# Altium schematic sheet [1]MCU.SchDoc([1]MCU)
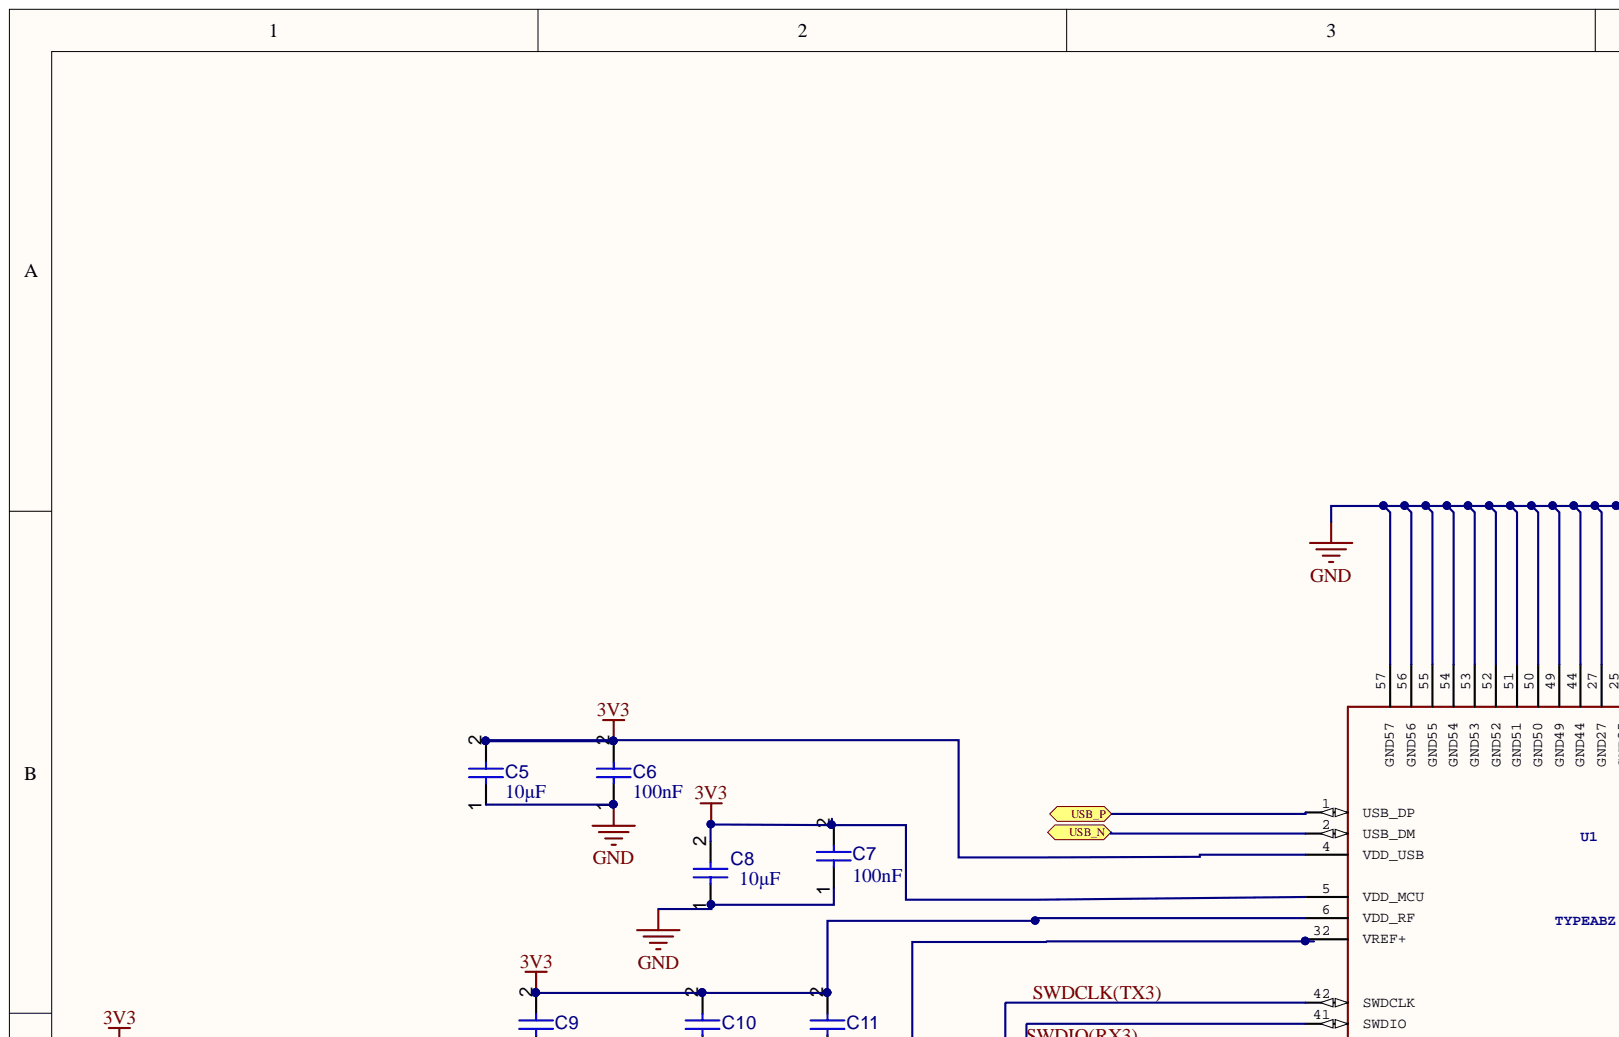
[diagram p0: [1]MCU.SchDoc, top left]
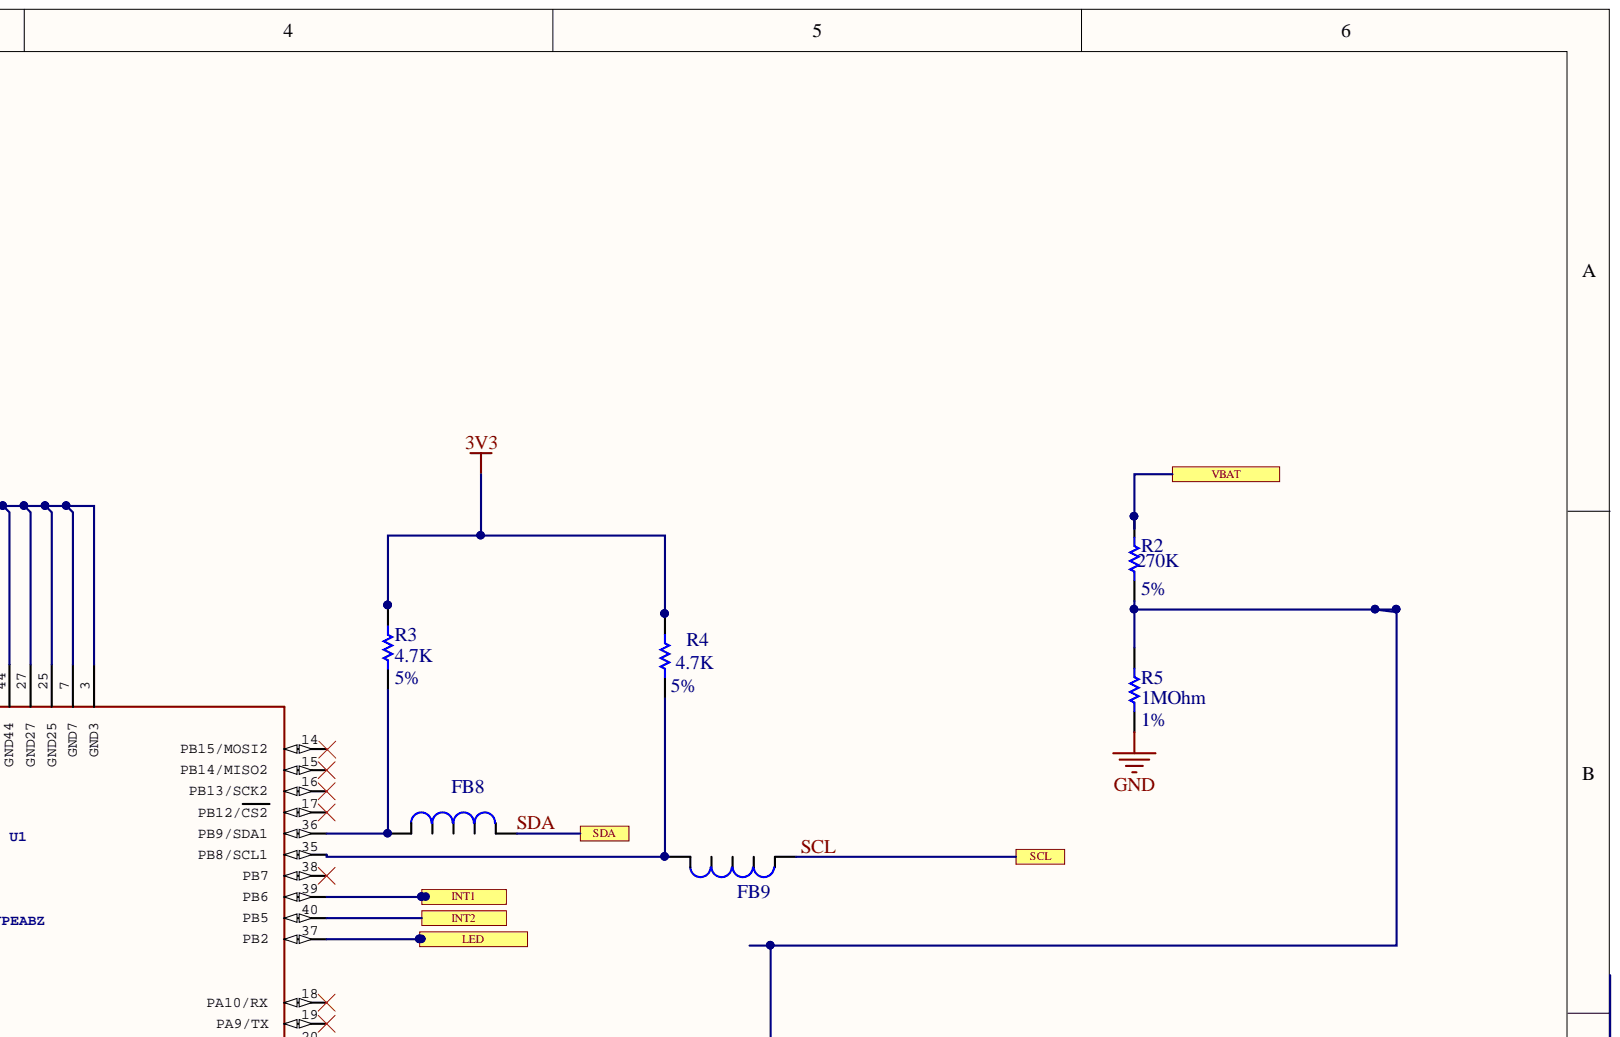
[diagram p1: [1]MCU.SchDoc, top right]
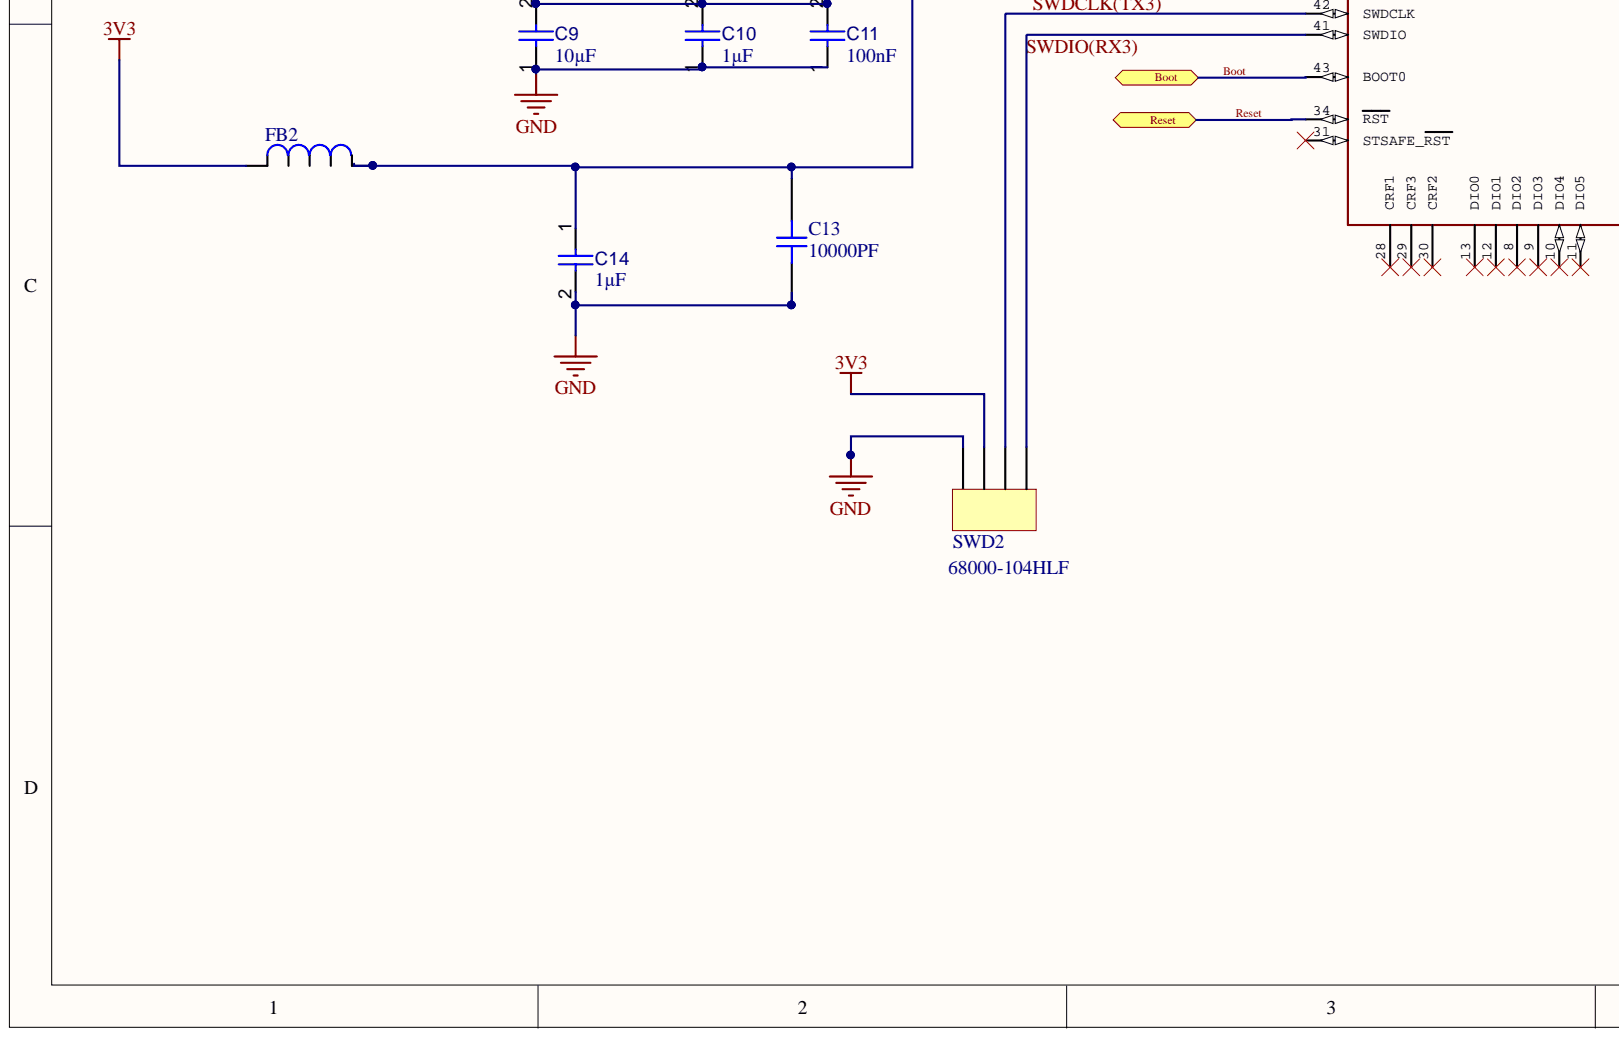
[diagram p2: [1]MCU.SchDoc, bottom left]
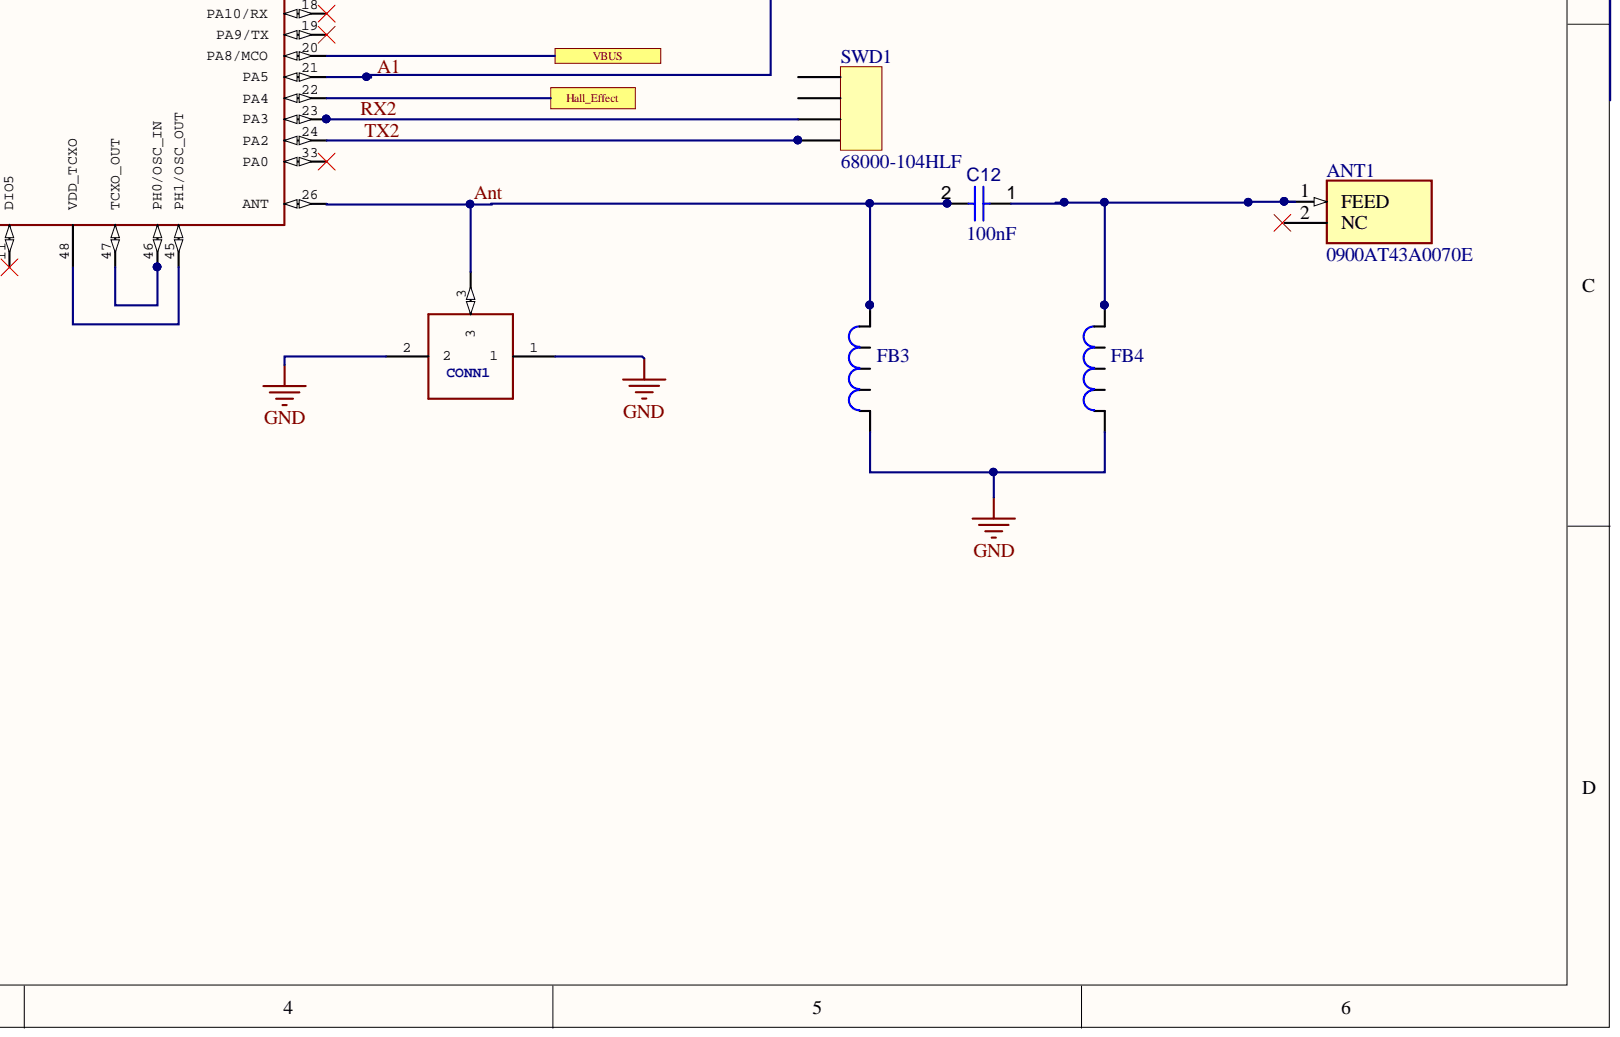
[diagram p3: [1]MCU.SchDoc, bottom right]

## Parts
ANT1  0900AT43A0070E  [ANTC7020X90N]
C5  value 10µF  [CAPC0603(1608)100_L]  (hidden comment: Capacitor 10µF +/-20% 10V 0603)
C6  value 100nF  [CAPC1608X90X40ML10T20]  (hidden comment: Capacitor 100 nF +/-10% 50 V 0603)
C7  value 100nF  [CAPC1608X90X40ML10T20]  (hidden comment: Capacitor 100 nF +/-10% 50 V 0603)
C8  value 10µF  [CAPC0603(1608)100_L]  (hidden comment: Capacitor 10µF +/-20% 10V 0603)
C9  value 10µF  [CAPC0603(1608)100_L]  (hidden comment: Capacitor 10µF +/-20% 10V 0603)
C10  value 1µF  [CAPC1608X90X40ML10T20]  (hidden comment: Capacitor 1 uF +/- 10% 25 V 0603)
C11  value 100nF  [CAPC1608X90X40ML10T20]  (hidden comment: Capacitor 100 nF +/-10% 50 V 0603)
C12  value 100nF  [CAPC1608X90X40ML10T20]  (hidden comment: Capacitor 100 nF +/-10% 50 V 0603)
C13  10000PF  [CAPC0603]
C14  value 1µF  [CAPC1608X90X40ML10T20]  (hidden comment: Capacitor 1 uF +/- 10% 25 V 0603)
CONN1  U.FL-R-SMT-1  [U.FL-R-SMT-1]  (value not drawn)
FB2  Ferrite Bead  [Ferrite Bead]  (value not drawn)
FB3  Ferrite Bead  [Ferrite Bead]  (value not drawn)
FB4  Ferrite Bead  [Ferrite Bead]  (value not drawn)
FB8  Ferrite Bead  [Ferrite Bead]  (value not drawn)
FB9  Ferrite Bead  [Ferrite Bead]  (value not drawn)
R2  value 270K  Tolerance: 5%  [RES 0603]  (hidden comment: RES 270K 5% 0.1W [0603])
R3  value 4.7K  Tolerance: 5%  [RES 0603]  (hidden comment: RES 4.7K 5% 0.1W [0603])
R4  value 4.7K  Tolerance: 5%  [RES 0603]  (hidden comment: RES 4.7K 5% 0.1W [0603])
R5  value 1MOhm  Tolerance: 1%  [RES 0603]  (hidden comment: RES 1M 5% 0.1W [0603])
SWD1  68000-104HLF  [4POS_HEADER]
SWD2  68000-104HLF  [4POS_HEADER]
U1  TYPEABZ  [CMWX1ZZABZ]

## Ports
Boot, Hall_Effect, INT1, INT2, LED, Reset, SCL, SDA, USB_N, USB_P, VBAT, VBUS

## Nets
3V3: C5.2 C6.2 C7.2 C8.2 C9.2 C10.2 C11.2 FB2.2 R3.2 R4.2 SWD2.2 U1.4(VDD_USB) U1.5(VDD_MCU) U1.6(VDD_RF)
GND: C5.1 C6.1 C7.1 C8.1 C9.1 C10.1 C11.1 C13.1 C14.2 CONN1.1 CONN1.2 FB3.2 FB4.2 R5.1 SWD2.1 U1.3(GND3) U1.7(GND7) U1.25(GND25) U1.27(GND27) U1.44(GND44) U1.49(GND49) U1.50(GND50) U1.51(GND51) U1.52(GND52) U1.53(GND53) U1.54(GND54) U1.55(GND55) U1.56(GND56) U1.57(GND57)
Boot: U1.43(BOOT0) ; port Boot
Hall_Effect: U1.22(PA4) ; port Hall_Effect
INT1: U1.39(PB6) ; port INT1
INT2: U1.40(PB5) ; port INT2
LED: U1.37(PB2) ; port LED
Reset: U1.34(RST) ; port Reset
RX2: SWD1.2 U1.23(PA3)
SCL: FB9.2 ; port SCL
SDA: FB8.2 ; port SDA
SWDIO(RX3): SWD2.4 U1.41(SWDIO)
TX2: SWD1.1 U1.24(PA2)
USB_N: U1.2(USB_DM) ; port USB_N
USB_P: U1.1(USB_DP) ; port USB_P
VBAT: R2.2 ; port VBAT
VBUS: U1.20(PA8/MCO) ; port VBUS
NetANT1_1: ANT1.1 C12.1 FB4.1
NetC12_2: C12.2 CONN1.3 FB3.1 U1.26(ANT)
NetC13_2: C13.2 C14.1 FB2.1 U1.32(VREF+)
NetFB8_1: FB8.1 R3.1 U1.36(PB9/SDA1)
NetFB9_1: FB9.1 R4.1 U1.35(PB8/SCL1)
NetR2_1: R2.1 R5.2 U1.21(PA5)
NetSWD2_3: SWD2.3 U1.42(SWDCLK)
NetU1_45: U1.45(PH1/OSC_OUT) U1.48(VDD_TCXO)
NetU1_46: U1.46(PH0/OSC_IN) U1.47(TCXO_OUT)
A1:
Ant:
N00006:
Reset:
SWDCLK(TX3):

Not connected: ANT1 2; SWD1 3 4; U1 8(DIO2) 9(DIO3) 10(DIO4) 11(DIO5) 12(DIO1) 13(DIO0) 14(PB15/MOSI2) 15(PB14/MISO2) 16(PB13/SCK2) 17(PB12/CS2) 18(PA10/RX) 19(PA9/TX) 28(CRF1) 29(CRF3) 30(CRF2) 31(STSAFE_RST) 33(PA0) 38(PB7)

## Library data of the parts
ANT1 = 0900AT43A0070E: Antenna; Manufacturer_Name: JOHANSON TECHNOLOGY; Manufacturer_Part_Number: 0900AT43A0070E; Library Name: Schlib_For_High_Voltage_Sensor.SchLib; Height: 0.9mm; Datasheet Link: https://www.johansontechnology.com/datasheets/0900AT43A0070/0900AT43A0070.pdf; Component Kind: Standard
C5 C8 C9 = CMP-001-00075-2: Chip Capacitor, 10µF +/-20%, 10V, 0603, Thickness 1 mm; Tolerance: 10%; Voltage Rating: 10V; Pins: 2; Mounting Technology: SMT; Min Operating Temperature: -55°C; Max Operating Temperature: 85°C; Component Kind: Standard; Case/Package: 0402; Library Name: Latium Technologies
C6 C7 C11 C12 = CMP-001-00017-4: Chip Capacitor, 100 nF, +/- 10%, 50 V, 0603 (1608 Metric); Voltage Rating: 50V; Capacitor Type: Ceramic; Tolerance: 10%; RoHS Compliant: True; Pins: 2; Mounting Technology: SM; Min Operating Temperature: -55°C; Max Operating Temperature: 125°C; Component Kind: Standard; Case/Package: 0603; Library Name: Latium Technologies
C10 C14 = CMP-001-00005-4: Chip Capacitor, 1 uF, +/- 10%, 25 V, 0603 (1608 Metric); Voltage Rating: 25V; Capacitor Type: Ceramic; Tolerance: 10%; RoHS Compliant: True; Pins: 2; Mounting Technology: SM; Min Operating Temperature: -55°C; Max Operating Temperature: 125°C; Component Kind: Standard; Case/Package: 0603; Library Name: Latium Technologies
C13 = GRM188R71H103KA01D: CAP CER 10000PF 50V X7R 0603; Manufacturer: Murata Electronics North America; Tolerance: ±10%; Voltage - Rated: 50V; Thickness (Max): 0.035" (0.90mm); Temperature Coefficient: X7R; Supplier Part Number 1: 490-1512-1-ND; Supplier 1: Digi-Key; Stock 1: 5417714; Size / Dimension: 0.063" L x 0.031" W (1.60mm x 0.80mm); RoHS: RoHS Compliant; Ratings: -; Pricing 1: 1=0.14, 10=0.031, 100=0.0135, 500=0.00966, 1000=0.00758 (CAD); Part Status: Active; Packaging: Cut Tape (CT); Package / Case: 0603 (1608 Metric); Operating Temperature: -55°C ~ 125°C; Mounting Type: Surface Mount, MLCC; Manufacturer Part Number: GRM188R71H103KA01D; Library Name: Schlib_For_High_Voltage_Sensor.SchLib; Lead Style: -; Lead Spacing: -; Height - Seated (Max): -; Features: -; ComponentLink1URL: http://www.murata.com/~/media/webrenewal/support/library/catalog/products/capacitor/mlcc/c02e.ashx; ComponentLink1Description: http://www.murata.com/~/media/webrenewal/support/library/catalog/products/capacitor/mlcc/c02e.ashx; Component Kind: Standard; Category: Capacitors; Capacitance: 10000pF; Applications: General Purpose
CONN1 = U.FL-R-SMT-1: GateName_1: G$1; SymbolName_1: U.FL-R-SMT-1; Library Name: Schlib_For_High_Voltage_Sensor.SchLib; DeviceSetName: U.FL-R-SMT-1; Description: U.FL-R-SMT-1; Component Kind: Standard; LibraryName: Kris
FB2-FB4 FB8 FB9 = Ferrite Bead: Ferrite Bead 1KOhm @100MHz; PN: BLM18AG102SN1D; Supplier Part Number 1: 81-BLM11A102S; Supplier 1: Mouser; Library Name: MasterLib.SchLib; Datasheet: https://www.murata.com/en-us/products/productdata/8796738650142/ENFA0003.pdf?src-supplier=Digi-Key; Component Kind: Standard
R2 R3 = RES 3.3M 1% 0.1W [0603]: PN: CRCW06033M30FKEA; Supplier Part Number 1: 541-3.30MHCT-ND; Supplier 1: Digi-Key; Library Name: MasterLib.SchLib; Component Kind: Standard
  R2: Description: RES 270K 5% 0.1W [0603]
  R3: Description: RES 4.7K 5% 0.1W [0603]
R4 = RES 3.3M 1% 0.1W [0603]: RES 4.7K5% 0.1W [0603]; PN: CRCW06033M30FKEA; Supplier Part Number 1: 541-3.30MHCT-ND; Supplier 1: Digi-Key; Library Name: MasterLib.SchLib; Component Kind: Standard
R5 = RES 1M 1% 0.1W [0603]: PN: CR0603-FX-1004ELF; Supplier Part Number 1: 652-CR0603FX-1004ELF; Supplier 1: Mouser; Power: 0.1W; Library Name: MasterLib.SchLib; Description: RES 1M 1% 0.1W [0603]; Datasheet: https://uk.rs-online.com/webdocs/0fcd/0900766b80fcdb72.pdf?src-supplier=RSComponents; Component Kind: Standard
SWD1 SWD2 = 68000-104HLF: 4 Positions Header, Unshrouded Connector 0.100" (2.54mm) Through Hole Gold or Gold, GXT™; Manufacturer 1: Amphenol FCI; Voltage Rating: 1.5kV; Number of Positions: 4; Mating Post Length: 5.84mm; Insulation Resistance: 5GR; Gender: Male; Current Rating: 3A; Connector Type: Male Pin; Termination Post Length: 2.41mm; Termination: Solder; Supplier Part Number 1: 609-3248-ND; Supplier 1: Digi-Key; Stock 1: 10785; REACH SVHC: No SVHC; Radiation Hardening: No; Pricing 1: 1=0.33, 10=0.316, 100=0.2212, 500=0.18964, 1000=0.15803, 5000=0.13545, 10000=0.12642 (USD); Pitch: 2.54mm; Packaging: Bulk; Package Quantity: 100; Orientation: Straight; Number of Rows: 1; Number of Contacts: 4; Mount: Through Hole; Min Operating Temperature: -65°C; Max Voltage Rating (AC): 1.5kV; Max Operating Temperature: 125°C; Manufacturer Part Number 1: 68000-104HLF; Length: 10.16mm; Housing Material: Thermoplastic; Housing Colour: Black; Flammability Rating: UL94 V-0; Description 1: 4 Positions Header, Unshrouded Connector 0.100" (2.54mm) Through Hole Gold or Gold, GXT™; Depth: 2.41mm; Contact Plating: Nickel,Gold,GXT; Contact Mating Length: 0.23inch; Contact Material: Bronze; Contact Gender: Male; ComponentLink4URL: https://datasheet.ciiva.com/728/68000-728879.pdf; ComponentLink4Description: https://datasheet.ciiva.com/728/68000-728879.pdf; ComponentLink3URL: https://datasheet.ciiva.com/46395/1914865-46395854.pdf; ComponentLink3Description: https://datasheet.ciiva.com/46395/1914865-46395854.pdf; ComponentLink2URL: https://datasheet.ciiva.com/10149/68000-10149830.pdf; ComponentLink2Description: https://datasheet.ciiva.com/10149/68000-10149830.pdf; ComponentLink1URL: https://datasheet.ciiva.com/16726/68000-16726213.pdf; ComponentLink1Description: https://datasheet.ciiva.com/16726/68000-16726213.pdf; Component Kind: Standard; Colour: Black; Library Name: OTX_Lib_Charger.SchLib
U1 = TYPEABZ: CMWX1ZZABZ-078; GateName_1: G$1; SymbolName_1: TYPEABZ; Library Name: Schlib_For_High_Voltage_Sensor.SchLib; DeviceSetName: TYPEABZ; Component Kind: Standard
